# Altium schematic sheet Ldr.SchDoc(Ldr)
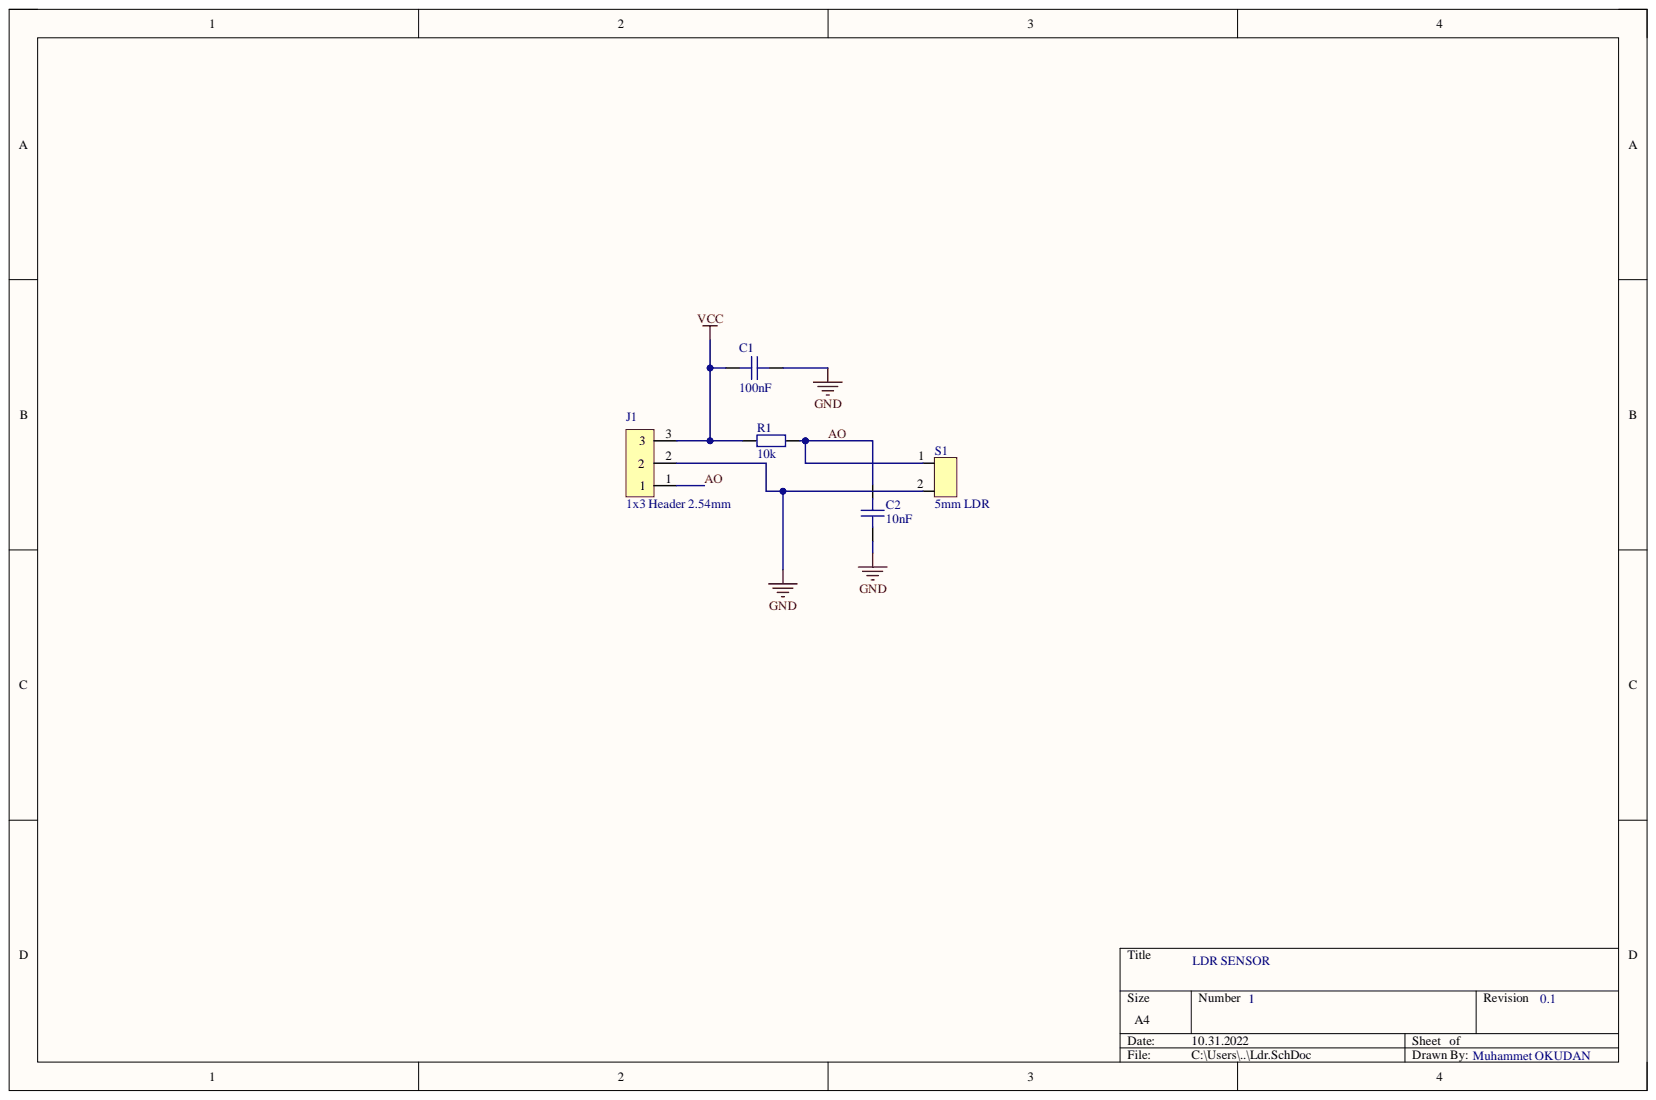
[diagram p0: Ldr.SchDoc]

## Parts
C1  100nF  [0603 CAP]
C2  10nF  [0603 CAP]
J1  1x3 Header 2.54mm  [1X3 Header 2.54mm]
R1  10k  [0603 resistor]
S1  5mm LDR  [LDR 5mm]

## Nets
GND: C1.2 C2.1 J1.2 S1.2
VCC: C1.1 J1.3 R1.2
AO: C2.2 J1.1 R1.1 S1.1

## Library data of the parts
C1 C2 = 0603 cap: Component Kind: Standard
  C1: Library Name: Schlib1.SchLib
  C2: Library Name: Ldr_Sensor.SchLib
R1 = Resistor 0603: Component Kind: Standard; Library Name: Schlib1.SchLib
S1 = Ldr 5mm: Component Kind: Standard; Library Name: Schlib1.SchLib
J1 = 1x3 Header 2.54mm: Component Kind: Standard; Library Name: Schlib1.SchLib
